# Altium schematic sheet PulseV3.SchDoc
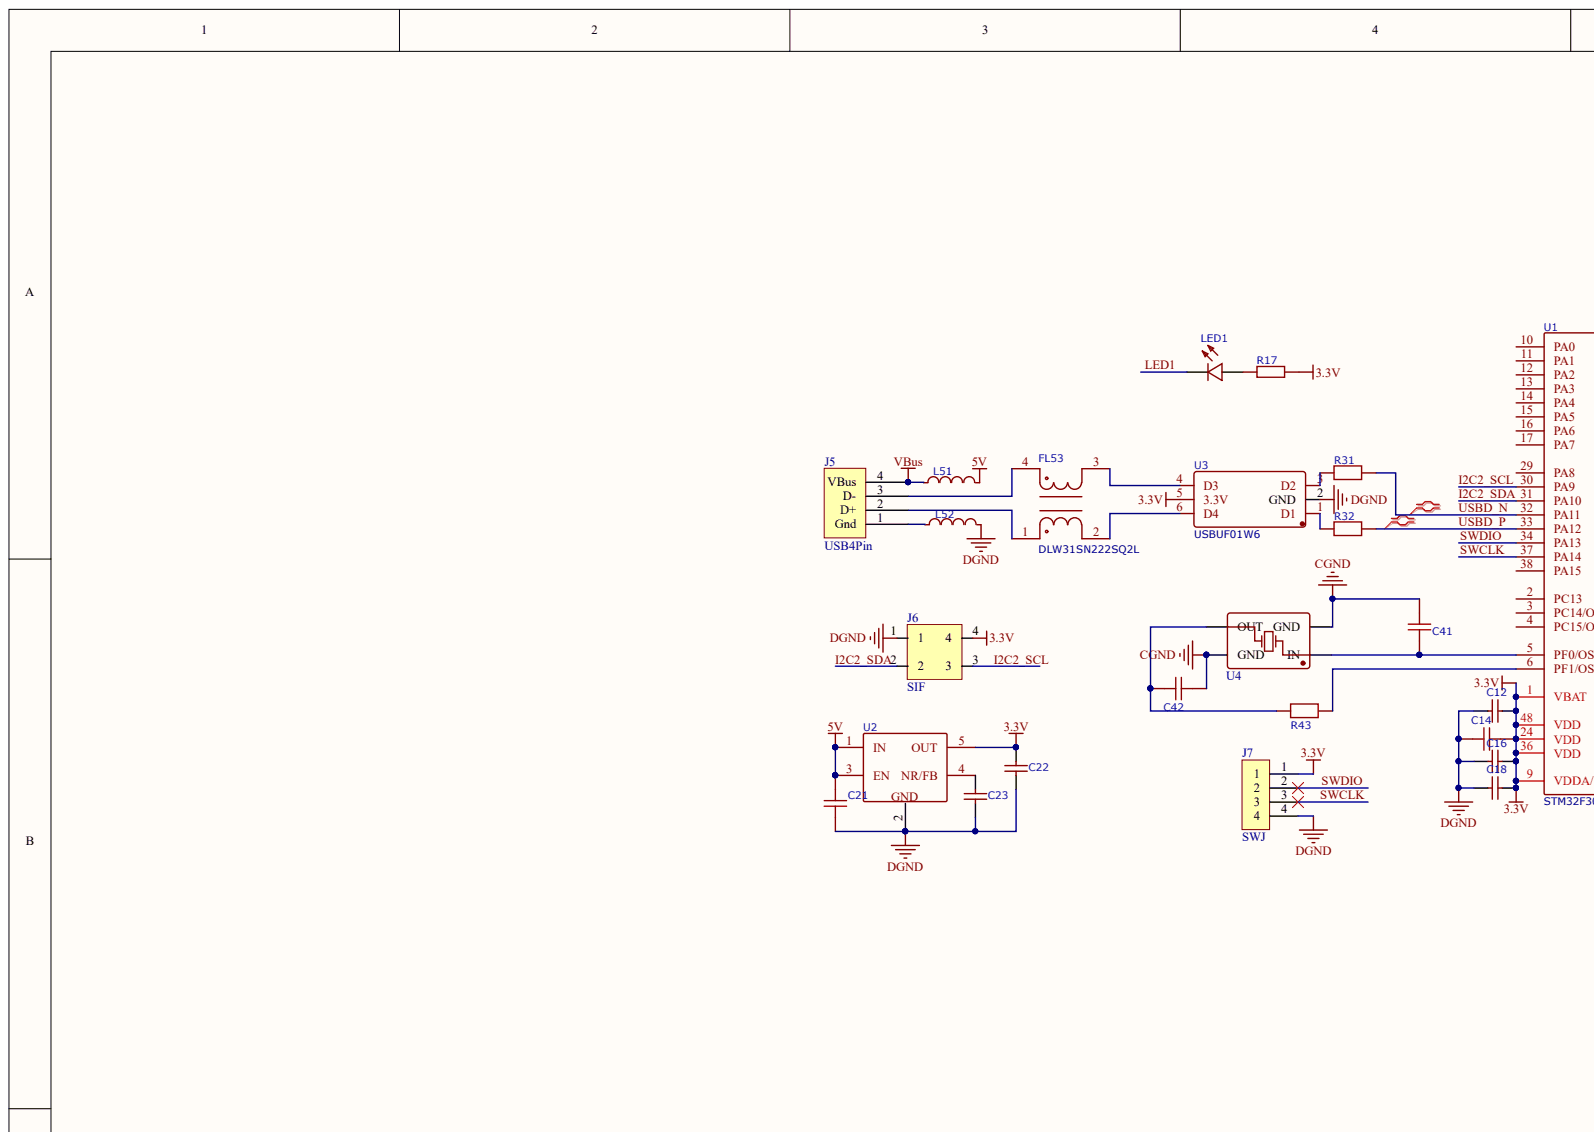
[diagram p0: PulseV3.SchDoc, top left]
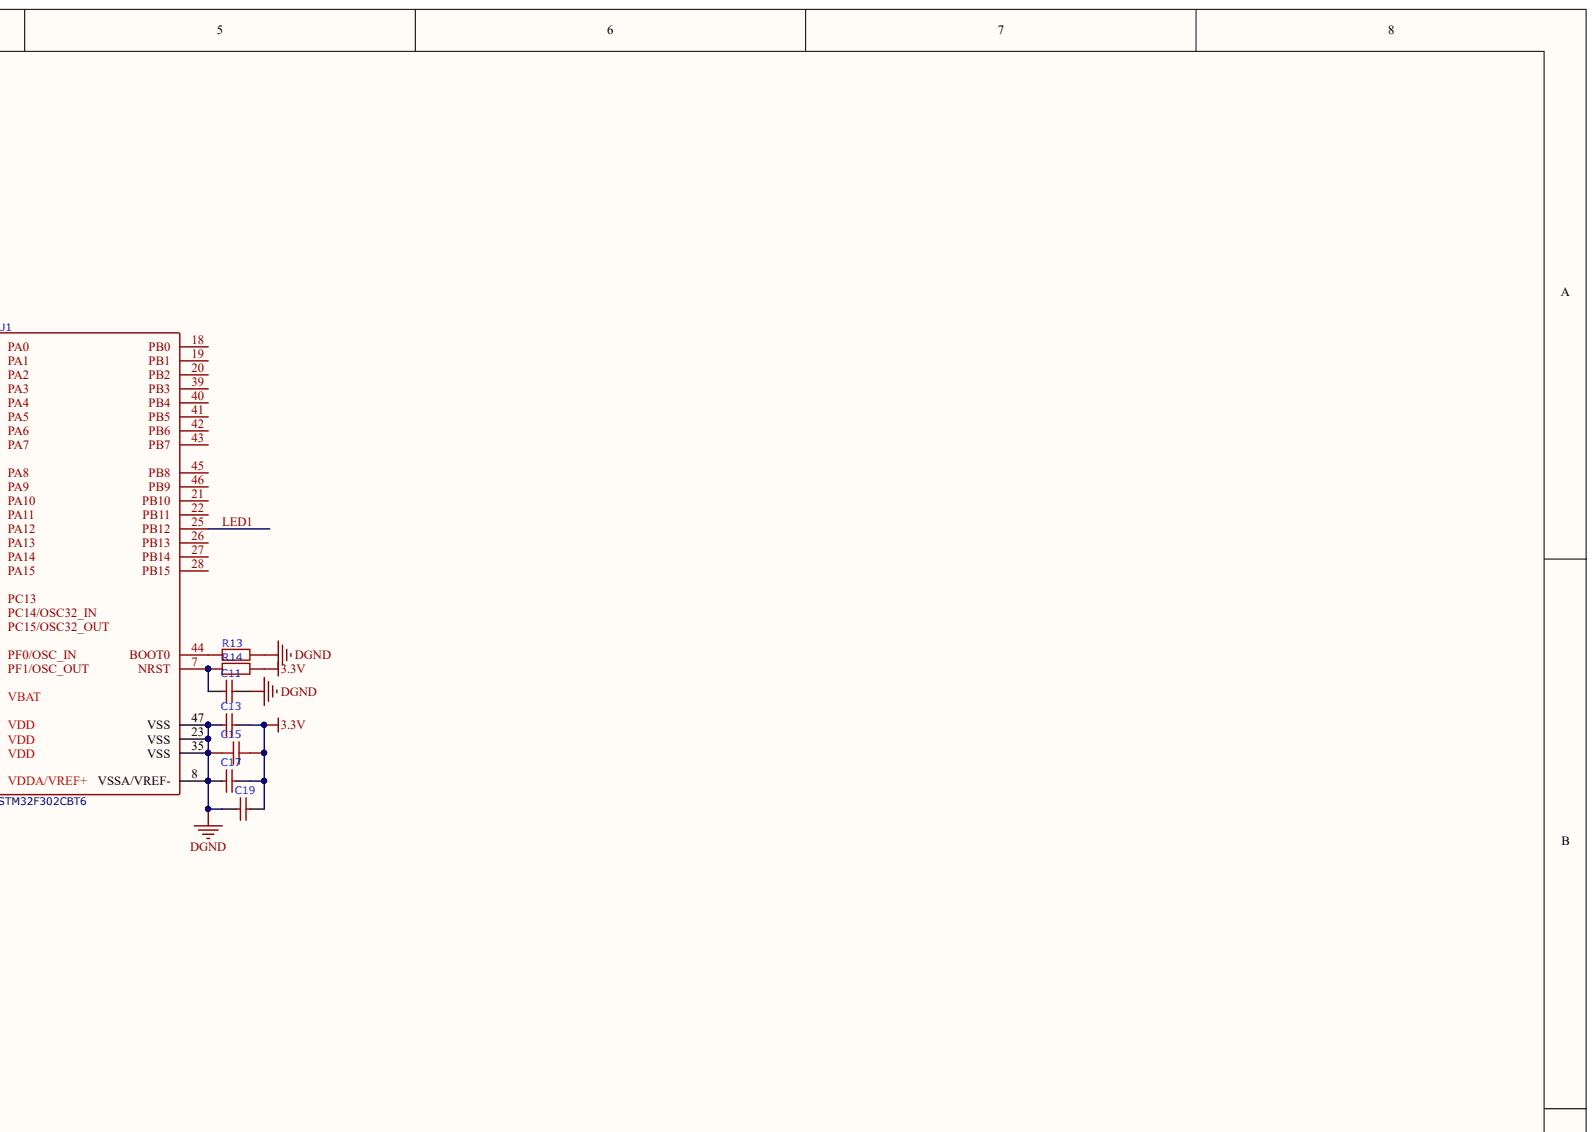
[diagram p1: PulseV3.SchDoc, top right]
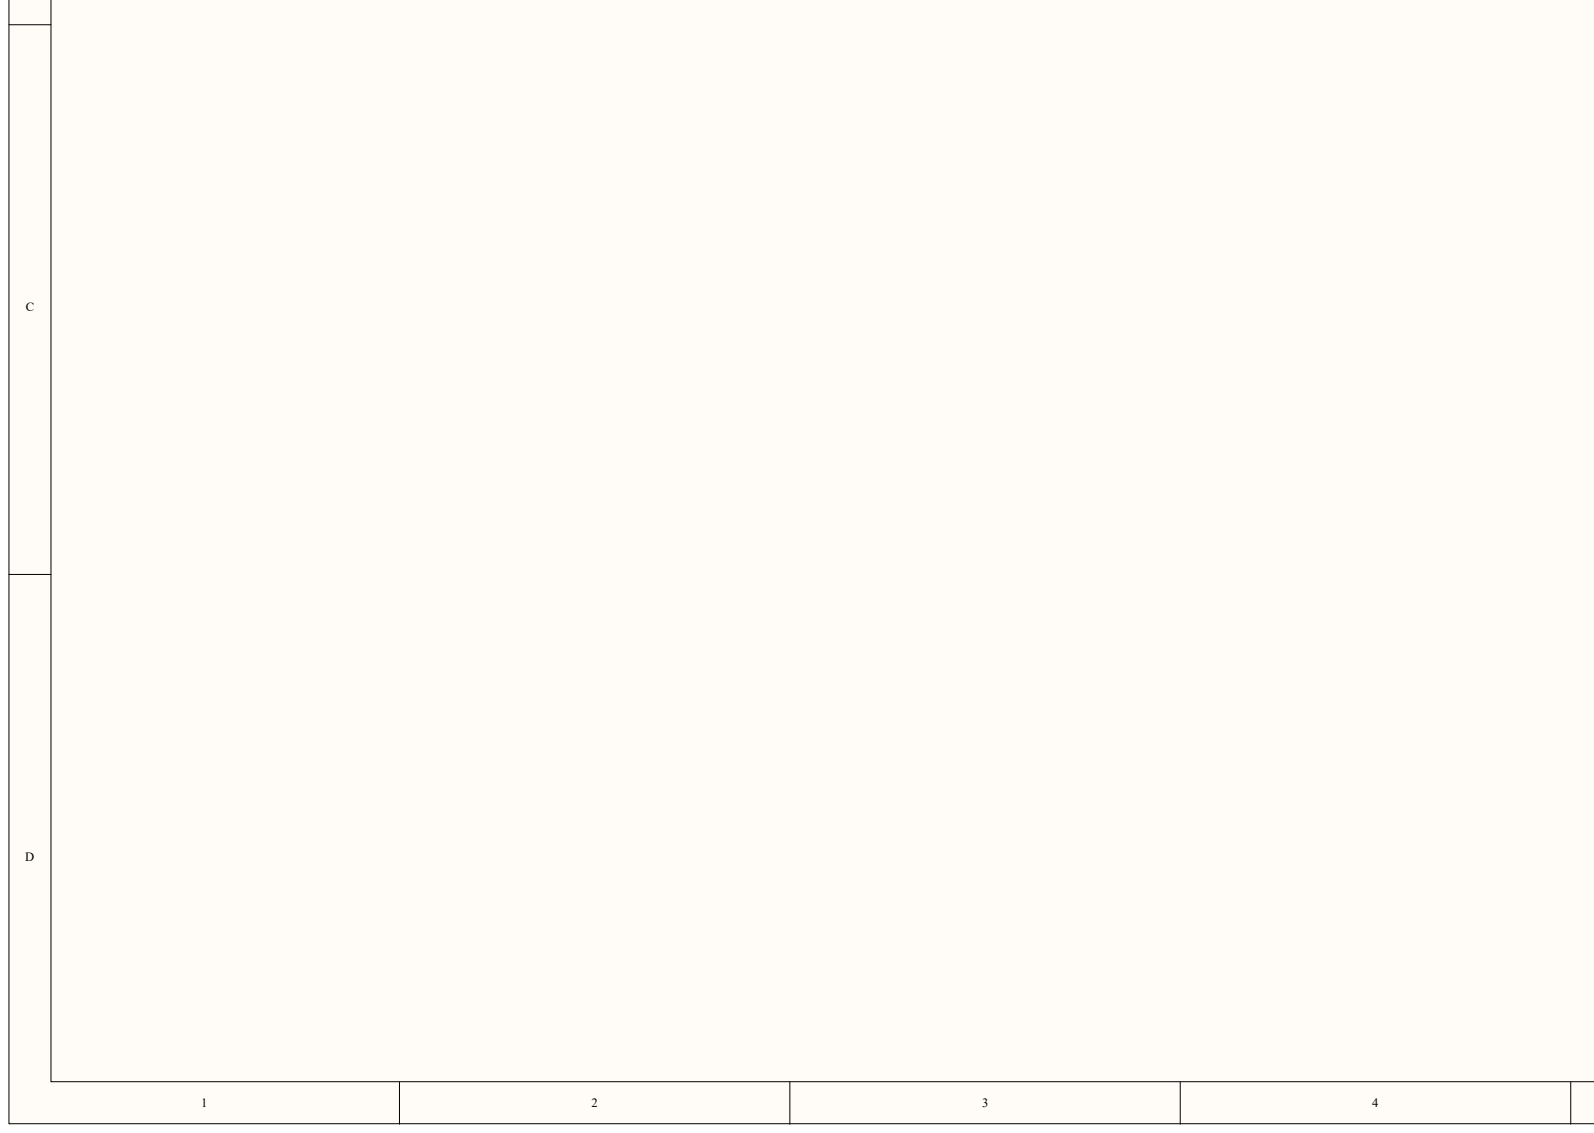
[diagram p2: PulseV3.SchDoc, bottom left]
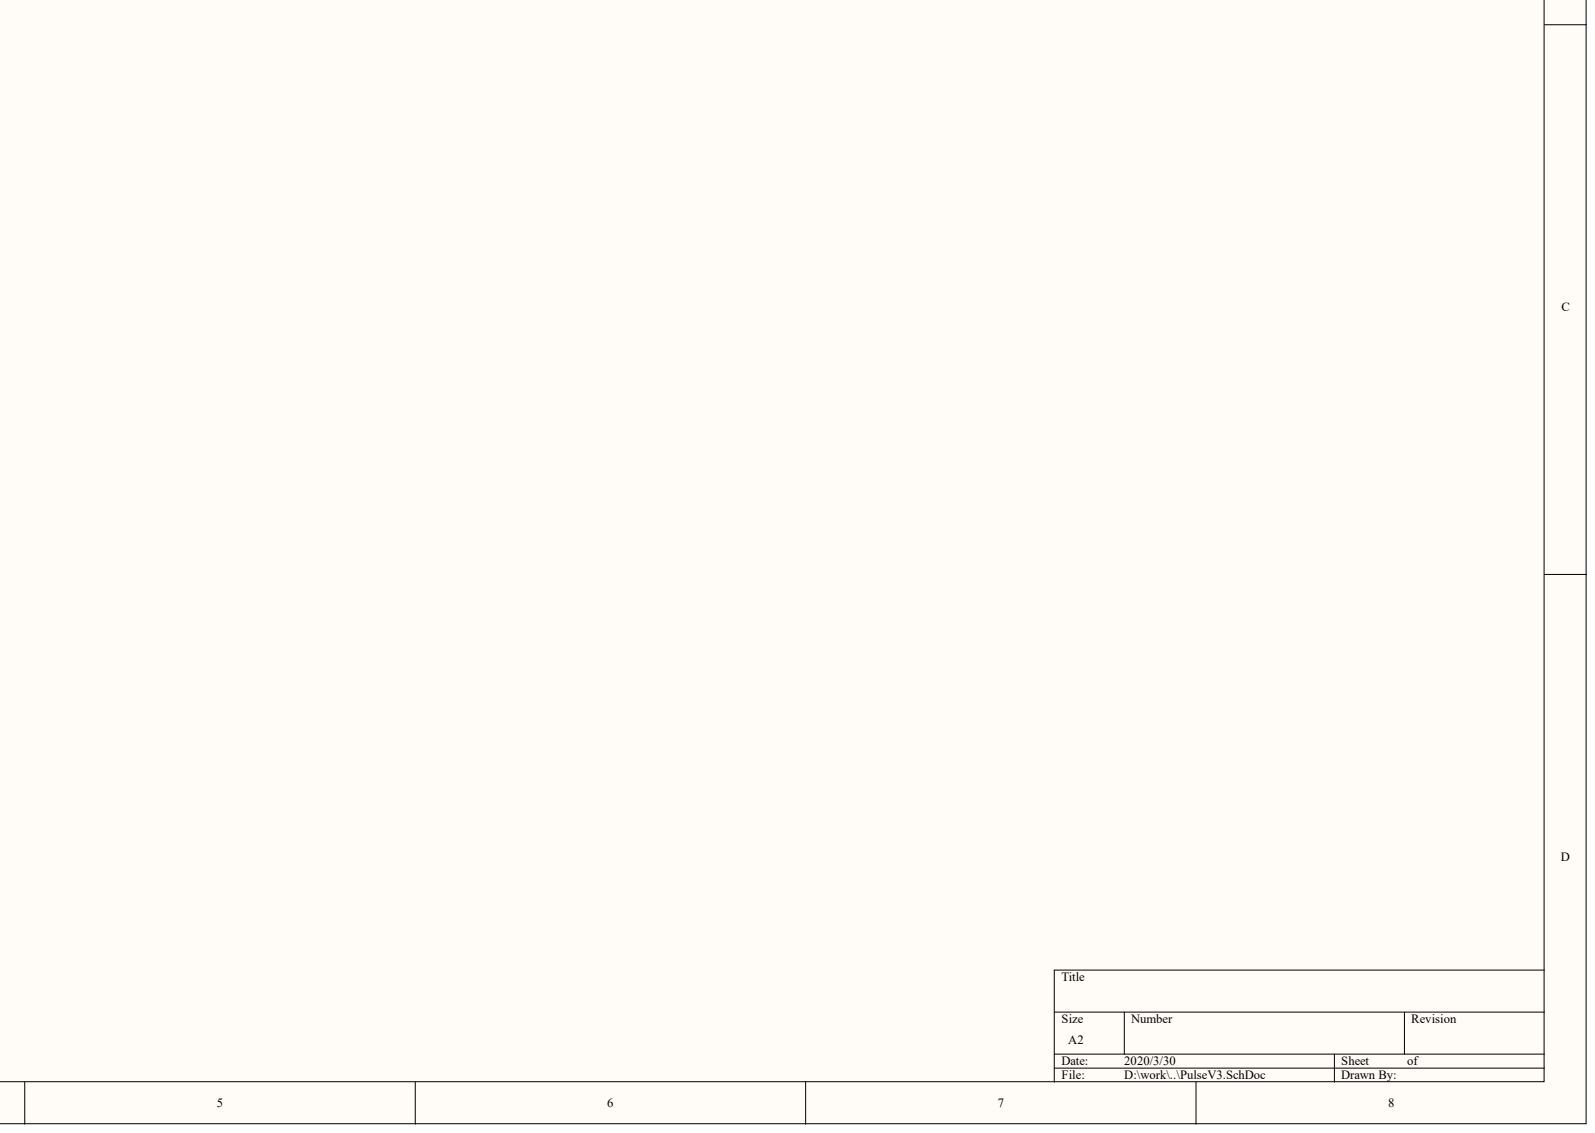
[diagram p3: PulseV3.SchDoc, bottom right]

## Parts
C11  GCM155R71E103KA37D  (value not drawn)
C12  GRM21BR61E226ME44L  (value not drawn)
C13  GRM21BR61E226ME44L  (value not drawn)
C14  GRM188R61E106KA73D  (value not drawn)
C15  GRM188R61E106KA73D  (value not drawn)
C16  GCM155R71E104KE02D  (value not drawn)
C17  GCM155R71E104KE02D  (value not drawn)
C18  GCM155R71E103KA37D  (value not drawn)
C19  GCM155R71E103KA37D  (value not drawn)
C21  GRM188R61E106KA73D  (value not drawn)
C22  GRM21BR61E226ME44L  (value not drawn)
C23  GCM155R71E103KA37D  (value not drawn)
C41  GJM1555C1H100GB01D  (value not drawn)
C42  GJM1555C1H100GB01D  (value not drawn)
FL53  DLW31SN222SQ2L
J5  USB4Pin
J6  SIF
J7  SWJ
L51  BLM18KG102SN1D  (value not drawn)
L52  BLM18KG102SN1D  (value not drawn)
LED1  19-217/BHC-ZL1M2RY/3T  (value not drawn)
R13  AC0402FR-0710KL  (value not drawn)
R14  AC0402FR-0710KL  (value not drawn)
R17  AC0402FR-071KL  (value not drawn)
R31  AC0402FR-0722RL  (value not drawn)
R32  AC0402FR-0722RL  (value not drawn)
R43  AC0402FR-0722RL  (value not drawn)
U1  STM32F302CBT6
U2  TPS73633DBVR  (value not drawn)
U3  USBUF01W6
U4  7B6A008000-103H100  (value not drawn)

## Nets
3.3V: C12.2 C13.2 C14.1 C15.1 C16.2 C17.2 C18.2 C19.2 C22.2 J6.4 J7.1 R14.2 R17.2 U1.1(VBAT) U1.9(VDDA/VREF+) U1.24(VDD) U1.36(VDD) U1.48(VDD) U2.5(OUT) U3.5(3.3V)
5V: C21.1 L51.2 U2.1(IN) U2.3(EN)
CGND: C41.2 C42.2 U4.2(GND) U4.4(GND)
DGND: C11.2 C12.1 C13.1 C14.2 C15.2 C16.1 C17.1 C18.1 C19.1 C21.2 C22.1 C23.1 J6.1 J7.4 L52.2 R13.2 U1.8(VSSA/VREF-) U1.23(VSS) U1.35(VSS) U1.47(VSS) U2.2 U3.2(GND)
VBUS: J5.4(VBus) L51.1
I2C2_SCL: J6.3 U1.30(PA9)
I2C2_SDA: J6.2 U1.31(PA10)
LED1: LED1.2 U1.25(PB12)
SWCLK: J7.3 U1.37(PA14)
SWDIO: J7.2 U1.34(PA13)
USBD_N: R31.2 U1.32(PA11)
USBD_P: R32.2 U1.33(PA12)
NetC11_1: C11.1 R14.1 U1.7(NRST)
NetC23_2: C23.2 U2.4(NR/FB)
NetC41_1: C41.1 U1.5(PF0/OSC_IN) U4.1(IN)
NetC42_1: C42.1 R43.2 U4.3(OUT)
NetFL53_1: FL53.1 J5.2(D+)
NetFL53_2: FL53.2 U3.6(D4)
NetFL53_3: FL53.3 U3.4(D3)
NetFL53_4: FL53.4 J5.3(D-)
NetJ5_1: J5.1(Gnd) L52.1
NetLED1_1: LED1.1 R17.1
NetR13_1: R13.1 U1.44(BOOT0)
NetR31_1: R31.1 U3.3(D2)
NetR32_1: R32.1 U3.1(D1)
NetR43_1: R43.1 U1.6(PF1/OSC_OUT)

Not connected: U1 2(PC13) 3(PC14/OSC32_IN) 4(PC15/OSC32_OUT) 10(PA0) 11(PA1) 12(PA2) 13(PA3) 14(PA4) 15(PA5) 16(PA6) 17(PA7) 18(PB0) 19(PB1) 20(PB2) 21(PB10) 22(PB11) 26(PB13) 27(PB14) 28(PB15) 29(PA8) 38(PA15) 39(PB3) 40(PB4) 41(PB5) 42(PB6) 43(PB7) 45(PB8) 46(PB9)
